# Altium schematic sheet LOGIC_FPGA.SchDoc
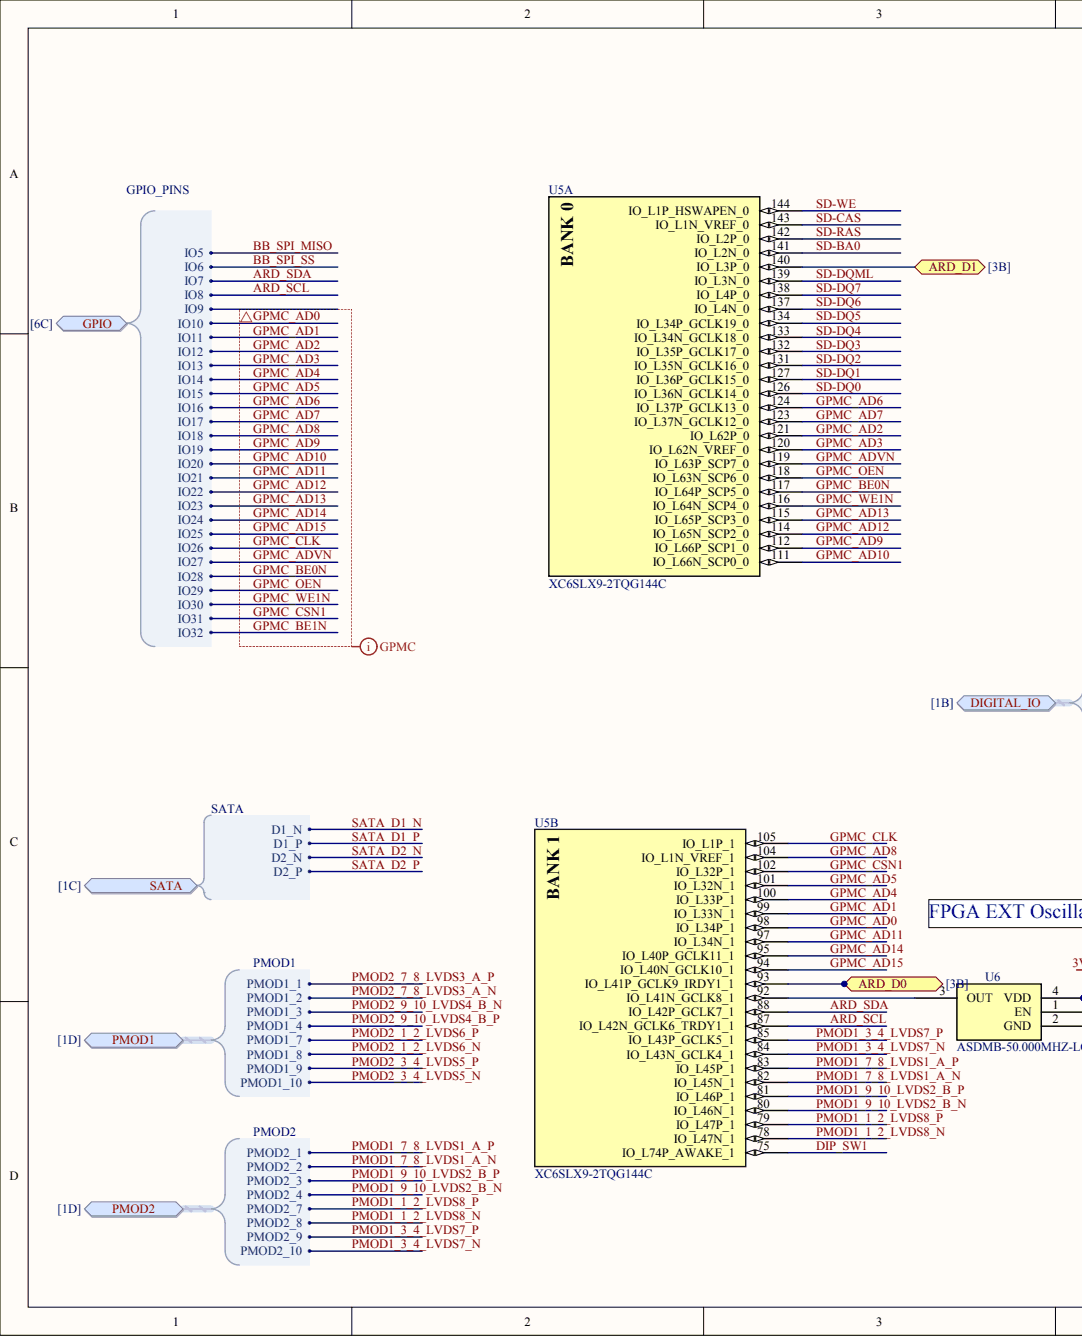
[diagram p0: LOGIC_FPGA.SchDoc, left]
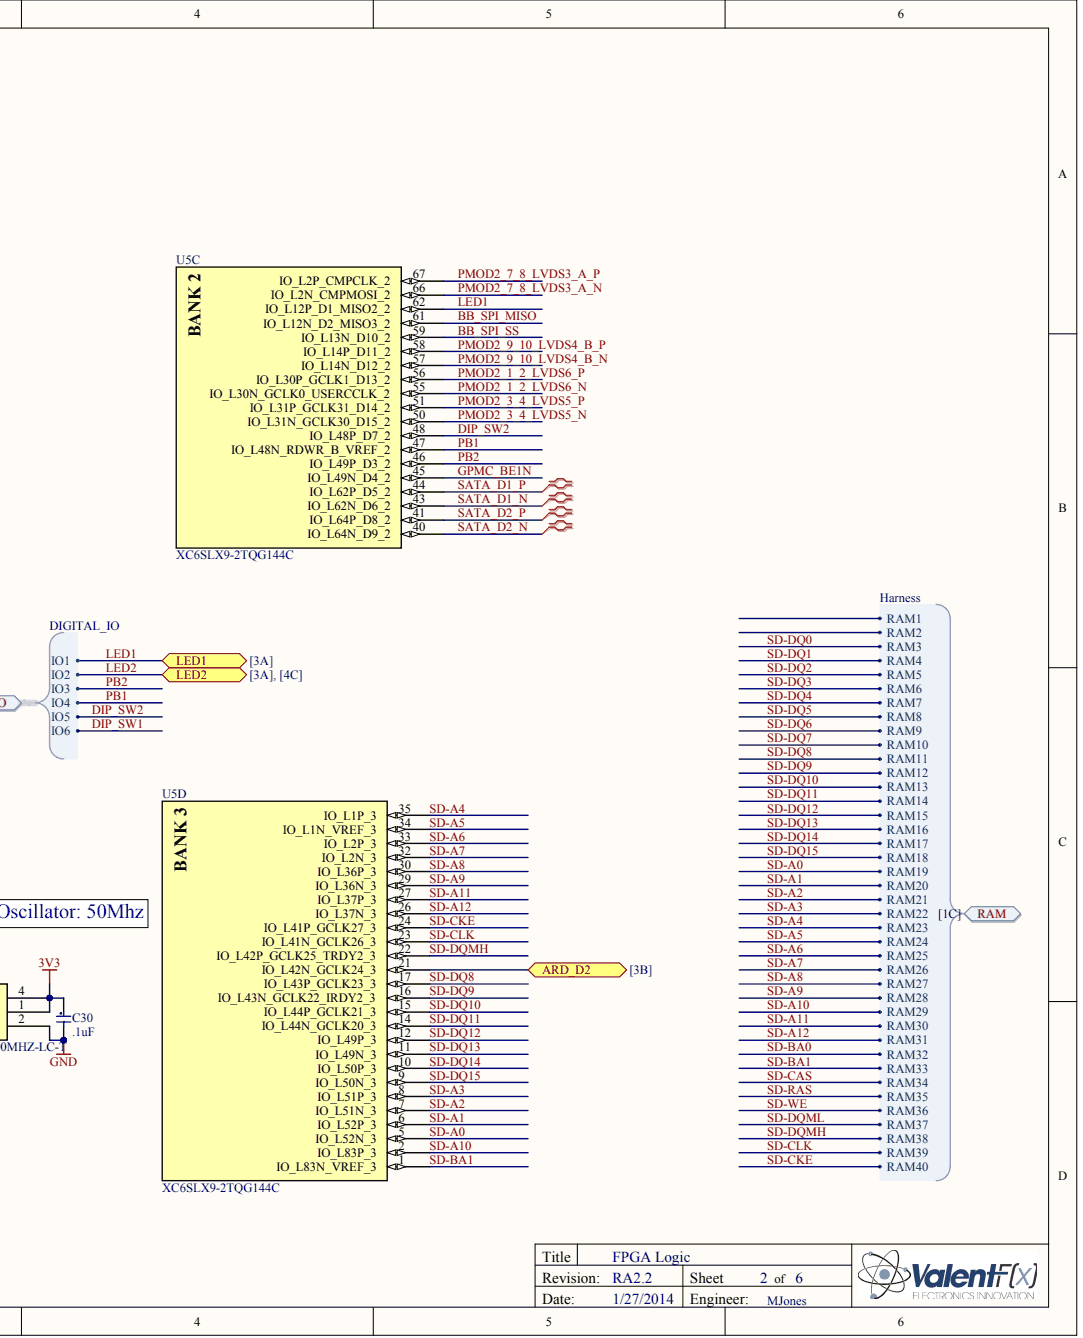
[diagram p1: LOGIC_FPGA.SchDoc, right]

## Parts
C30  .1uF
U5  XC6SLX9-2TQG144C  (parts A,B,C,D)
U6  ASDMB-50.000MHZ-LC-T

## Ports
ARD_D0, ARD_D1, ARD_D2, DIGITAL_IO, DIGITAL_IO.IO1, DIGITAL_IO.IO2, DIGITAL_IO.IO3, DIGITAL_IO.IO4, DIGITAL_IO.IO5, DIGITAL_IO.IO6, GPIO, GPIO.IO5, GPIO.IO6, GPIO.IO7, GPIO.IO8, GPIO.IO9, GPIO.IO10, GPIO.IO11, GPIO.IO12, GPIO.IO13, GPIO.IO14, GPIO.IO15, GPIO.IO16, GPIO.IO17, GPIO.IO18, GPIO.IO19, GPIO.IO20, GPIO.IO21, GPIO.IO22, GPIO.IO23, GPIO.IO24, GPIO.IO25, GPIO.IO26, GPIO.IO27, GPIO.IO28, GPIO.IO29, GPIO.IO30, GPIO.IO31, GPIO.IO32, LED1, LED2, PMOD1, PMOD1.PMOD1_1, PMOD1.PMOD1_2, PMOD1.PMOD1_3, PMOD1.PMOD1_4, PMOD1.PMOD1_7, PMOD1.PMOD1_8, PMOD1.PMOD1_9, PMOD1.PMOD1_10, PMOD2, PMOD2.PMOD2_1, PMOD2.PMOD2_2, PMOD2.PMOD2_3, PMOD2.PMOD2_4, PMOD2.PMOD2_7, PMOD2.PMOD2_8, PMOD2.PMOD2_9, PMOD2.PMOD2_10, RAM, RAM.RAM1, RAM.RAM2, RAM.RAM3, RAM.RAM4, RAM.RAM5, RAM.RAM6, RAM.RAM7, RAM.RAM8, RAM.RAM9, RAM.RAM10, RAM.RAM11, RAM.RAM12, RAM.RAM13, RAM.RAM14, RAM.RAM15, RAM.RAM16, RAM.RAM17, RAM.RAM18, RAM.RAM19, RAM.RAM20, RAM.RAM21, RAM.RAM22, RAM.RAM23, RAM.RAM24, RAM.RAM25, RAM.RAM26, RAM.RAM27, RAM.RAM28, RAM.RAM29, RAM.RAM30, RAM.RAM31, RAM.RAM32, RAM.RAM33, RAM.RAM34, RAM.RAM35, RAM.RAM36, RAM.RAM37, RAM.RAM38, RAM.RAM39, RAM.RAM40, SATA, SATA.D1_N, SATA.D1_P, SATA.D2_N, SATA.D2_P

## Nets
3V3: C30.1 U6.1(EN) U6.4(VDD)
GND: C30.2 U6.2(GND)
ARD_D0: U5.93(IO_L41P_GCLK9_IRDY1_1) ; port ARD_D0
ARD_D1: U5.140(IO_L3P_0) ; port ARD_D1
ARD_D2: U5.21(IO_L42N_GCLK24_3) ; port ARD_D2
ARD_SCL: U5.87(IO_L42N_GCLK6_TRDY1_1) ; port GPIO
ARD_SDA: U5.88(IO_L42P_GCLK7_1) ; port GPIO
BB_SPI_MISO: U5.61(IO_L12N_D2_MISO3_2) ; port GPIO
BB_SPI_SS: U5.59(IO_L13N_D10_2) ; port GPIO
DIP_SW1: U5.75(IO_L74P_AWAKE_1) ; port DIGITAL_IO
DIP_SW2: U5.48(IO_L48P_D7_2) ; port DIGITAL_IO
GPMC_AD0: U5.98(IO_L34P_1) ; port GPIO
GPMC_AD1: U5.99(IO_L33N_1) ; port GPIO
GPMC_AD2: U5.121(IO_L62P_0) ; port GPIO
GPMC_AD3: U5.120(IO_L62N_VREF_0) ; port GPIO
GPMC_AD4: U5.100(IO_L33P_1) ; port GPIO
GPMC_AD5: U5.101(IO_L32N_1) ; port GPIO
GPMC_AD6: U5.124(IO_L37P_GCLK13_0) ; port GPIO
GPMC_AD7: U5.123(IO_L37N_GCLK12_0) ; port GPIO
GPMC_AD8: U5.104(IO_L1N_VREF_1) ; port GPIO
GPMC_AD9: U5.112(IO_L66P_SCP1_0) ; port GPIO
GPMC_AD10: U5.111(IO_L66N_SCP0_0) ; port GPIO
GPMC_AD11: U5.97(IO_L34N_1) ; port GPIO
GPMC_AD12: U5.114(IO_L65N_SCP2_0) ; port GPIO
GPMC_AD13: U5.115(IO_L65P_SCP3_0) ; port GPIO
GPMC_AD14: U5.95(IO_L40P_GCLK11_1) ; port GPIO
GPMC_AD15: U5.94(IO_L40N_GCLK10_1) ; port GPIO
GPMC_ADVN: U5.119(IO_L63P_SCP7_0) ; port GPIO
GPMC_BE0N: U5.117(IO_L64P_SCP5_0) ; port GPIO
GPMC_BE1N: U5.45(IO_L49N_D4_2) ; port GPIO
GPMC_CLK: U5.105(IO_L1P_1) ; port GPIO
GPMC_CSN1: U5.102(IO_L32P_1) ; port GPIO
GPMC_OEN: U5.118(IO_L63N_SCP6_0) ; port GPIO
GPMC_WE1N: U5.116(IO_L64N_SCP4_0) ; port GPIO
LED1: U5.62(IO_L12P_D1_MISO2_2) ; port DIGITAL_IO, port LED1
PB1: U5.47(IO_L48N_RDWR_B_VREF_2) ; port DIGITAL_IO
PB2: U5.46(IO_L49P_D3_2) ; port DIGITAL_IO
PMOD1_1_2_LVDS8_N: U5.78(IO_L47N_1) ; port PMOD2
PMOD1_1_2_LVDS8_P: U5.79(IO_L47P_1) ; port PMOD2
PMOD1_3_4_LVDS7_N: U5.84(IO_L43N_GCLK4_1) ; port PMOD2
PMOD1_3_4_LVDS7_P: U5.85(IO_L43P_GCLK5_1) ; port PMOD2
PMOD1_7_8_LVDS1_A_N: U5.82(IO_L45N_1) ; port PMOD2
PMOD1_7_8_LVDS1_A_P: U5.83(IO_L45P_1) ; port PMOD2
PMOD1_9_10_LVDS2_B_N: U5.80(IO_L46N_1) ; port PMOD2
PMOD1_9_10_LVDS2_B_P: U5.81(IO_L46P_1) ; port PMOD2
PMOD2_1_2_LVDS6_N: U5.55(IO_L30N_GCLK0_USERCCLK_2) ; port PMOD1
PMOD2_1_2_LVDS6_P: U5.56(IO_L30P_GCLK1_D13_2) ; port PMOD1
PMOD2_3_4_LVDS5_N: U5.50(IO_L31N_GCLK30_D15_2) ; port PMOD1
PMOD2_3_4_LVDS5_P: U5.51(IO_L31P_GCLK31_D14_2) ; port PMOD1
PMOD2_7_8_LVDS3_A_N: U5.66(IO_L2N_CMPMOSI_2) ; port PMOD1
PMOD2_7_8_LVDS3_A_P: U5.67(IO_L2P_CMPCLK_2) ; port PMOD1
PMOD2_9_10_LVDS4_B_N: U5.57(IO_L14N_D12_2) ; port PMOD1
PMOD2_9_10_LVDS4_B_P: U5.58(IO_L14P_D11_2) ; port PMOD1
SATA_D1_N: U5.43(IO_L62N_D6_2) ; port SATA
SATA_D1_P: U5.44(IO_L62P_D5_2) ; port SATA
SATA_D2_N: U5.40(IO_L64N_D9_2) ; port SATA
SATA_D2_P: U5.41(IO_L64P_D8_2) ; port SATA
SD-A0: U5.5(IO_L52N_3) ; port RAM
SD-A1: U5.6(IO_L52P_3) ; port RAM
SD-A2: U5.7(IO_L51N_3) ; port RAM
SD-A3: U5.8(IO_L51P_3) ; port RAM
SD-A4: U5.35(IO_L1P_3) ; port RAM
SD-A5: U5.34(IO_L1N_VREF_3) ; port RAM
SD-A6: U5.33(IO_L2P_3) ; port RAM
SD-A7: U5.32(IO_L2N_3) ; port RAM
SD-A8: U5.30(IO_L36P_3) ; port RAM
SD-A9: U5.29(IO_L36N_3) ; port RAM
SD-A10: U5.2(IO_L83P_3) ; port RAM
SD-A11: U5.27(IO_L37P_3) ; port RAM
SD-A12: U5.26(IO_L37N_3) ; port RAM
SD-BA0: U5.141(IO_L2N_0) ; port RAM
SD-BA1: U5.1(IO_L83N_VREF_3) ; port RAM
SD-CAS: U5.143(IO_L1N_VREF_0) ; port RAM
SD-CKE: U5.24(IO_L41P_GCLK27_3) ; port RAM
SD-CLK: U5.23(IO_L41N_GCLK26_3) ; port RAM
SD-DQ0: U5.126(IO_L36N_GCLK14_0) ; port RAM
SD-DQ1: U5.127(IO_L36P_GCLK15_0) ; port RAM
SD-DQ2: U5.131(IO_L35N_GCLK16_0) ; port RAM
SD-DQ3: U5.132(IO_L35P_GCLK17_0) ; port RAM
SD-DQ4: U5.133(IO_L34N_GCLK18_0) ; port RAM
SD-DQ5: U5.134(IO_L34P_GCLK19_0) ; port RAM
SD-DQ6: U5.137(IO_L4N_0) ; port RAM
SD-DQ7: U5.138(IO_L4P_0) ; port RAM
SD-DQ8: U5.17(IO_L43P_GCLK23_3) ; port RAM
SD-DQ9: U5.16(IO_L43N_GCLK22_IRDY2_3) ; port RAM
SD-DQ10: U5.15(IO_L44P_GCLK21_3) ; port RAM
SD-DQ11: U5.14(IO_L44N_GCLK20_3) ; port RAM
SD-DQ12: U5.12(IO_L49P_3) ; port RAM
SD-DQ13: U5.11(IO_L49N_3) ; port RAM
SD-DQ14: U5.10(IO_L50P_3) ; port RAM
SD-DQ15: U5.9(IO_L50N_3) ; port RAM
SD-DQMH: U5.22(IO_L42P_GCLK25_TRDY2_3) ; port RAM
SD-DQML: U5.139(IO_L3N_0) ; port RAM
SD-RAS: U5.142(IO_L2P_0) ; port RAM
SD-WE: U5.144(IO_L1P_HSWAPEN_0) ; port RAM
NetU5_92: U5.92(IO_L41N_GCLK8_1) U6.3(OUT)
LED2: ; port DIGITAL_IO, port LED2
N00041: ; port RAM
N00042: ; port RAM
N00043:
N00044: ; port GPIO

## Library data of the parts
C30 = (no symbol name): Manufacturer: Murata; Manufacturer Part Number: GRM155R61C104KA88D; Capacitance: 0.1 uF; Tolerance: 10 %; Voltage Rating: 16 Volts; Temperature Coefficient / Code: X5R; Value: .1uF; Supplier 1: Mouser; Supplier Part Number 1: 81-GRM155R61C104KA8D; RoHS: RoHS Compliant By Exemption; Category: Multilayer Ceramic Capacitors (MLCC) - SMD/SMT; Stock 1: 478408; Pricing 1: 1=0.122, 50=0.034, 100=0.026, 500=0.014, 1000=0.009, 10000=0.005, 20000=0.004 (AUD); Package / Case: 0402 (1005 metric); Packaging: Reel; ComponentLink1URL: http://www.murata.com/products/catalog/pdf/c02e.pdf; ComponentLink1Description: http://www.murata.com/products/catalog/pdf/c02e.pdf; Dimensions: 0.5 mm W x 1 mm L x 0.5 mm H; Operating Temperature Range: - 55 C to + 85 C; Product: General Type MLCCs; Series: GRM; Termination Style: SMD/SMT; Product Category: Low & Medium Capacitance SMD Ceramic Chip Capacitors; Standard Pack Qty: 10000; RoHS - Mouser: E; Minimum Order Quantity: 1; Reeling: True
U5 = (no symbol name): Manufacturer: Xilinx Inc; Number of Logic Elements/Cells: 9152; Number of LABs/CLBs: 715; Total RAM Bits: 589824; Number of I /O: 102; Voltage - Supply: 1.14 V ~ 1.26 V; Supplier 1: America II Electronics; Supplier Part Number 1: 122-1745-ND; Manufacturer Part Number: XC6SLX9-2TQG144C; RoHS: RoHS Compliant; Category: Integrated Circuits (ICs); Stock 1: 994; Pricing 1: 1=12.80,1=11.05,1000=9.59 (USD); Number of Gates: -; Mounting Type: Surface Mount; Operating Temperature: 0°C ~ 85°C; Package / Case: 144-LQFP; Supplier Device Package: 144-TQFP (20x20); ComponentLink1URL: http://www.xilinx.com/support/documentation/data_sheets/ds160.pdf; ComponentLink1Description: http://www.xilinx.com/support/documentation/data_sheets/ds160.pdf; Value: XC6SLX9-2TQG144C; Supplier 2: Digi-Key
U6 = (no symbol name): Manufacturer: Abracon Corporation; Frequency: 50MHz; Function: Tri-State (Output Enable); Output: CMOS; Voltage - Supply: 1.8 V ~ 3.3 V; Frequency Stability: ±50ppm; Current - Supply (Max): 16mA; Current - Supply (Disable) (Max): 15µA; Supplier 1: Digi-Key; Manufacturer Part Number: ASDMB-50.000MHZ-LC-T; RoHS: RoHS Compliant; Category: Crystals and Oscillators; Stock 1: 967; Pricing 1: 1=1.69, 10=1.463, 50=1.2826, 100=1.0125, 500=0.855 (USD); Type: MEMS (Silicon); Operating Temperature: -40°C ~ 85°C; Mounting Type: Surface Mount; Size / Dimension: 0.098" L x 0.079" W (2.50mm x 2.00mm); Height: 0.035" (0.90mm); Package / Case: 4-SMD, No Lead (DFN, LCC); Packaging: Cut Tape (CT); ComponentLink1URL: http://www.abracon.com/Oscillators/ASDMB.pdf; ComponentLink1Description: http://www.abracon.com/Oscillators/ASDMB.pdf; Supplier Part Number 1: 535-11738-1-ND; Value: ASDMB-50.000MHZ-LC-T
